# Altium schematic sheet TI_92_power.SchDoc
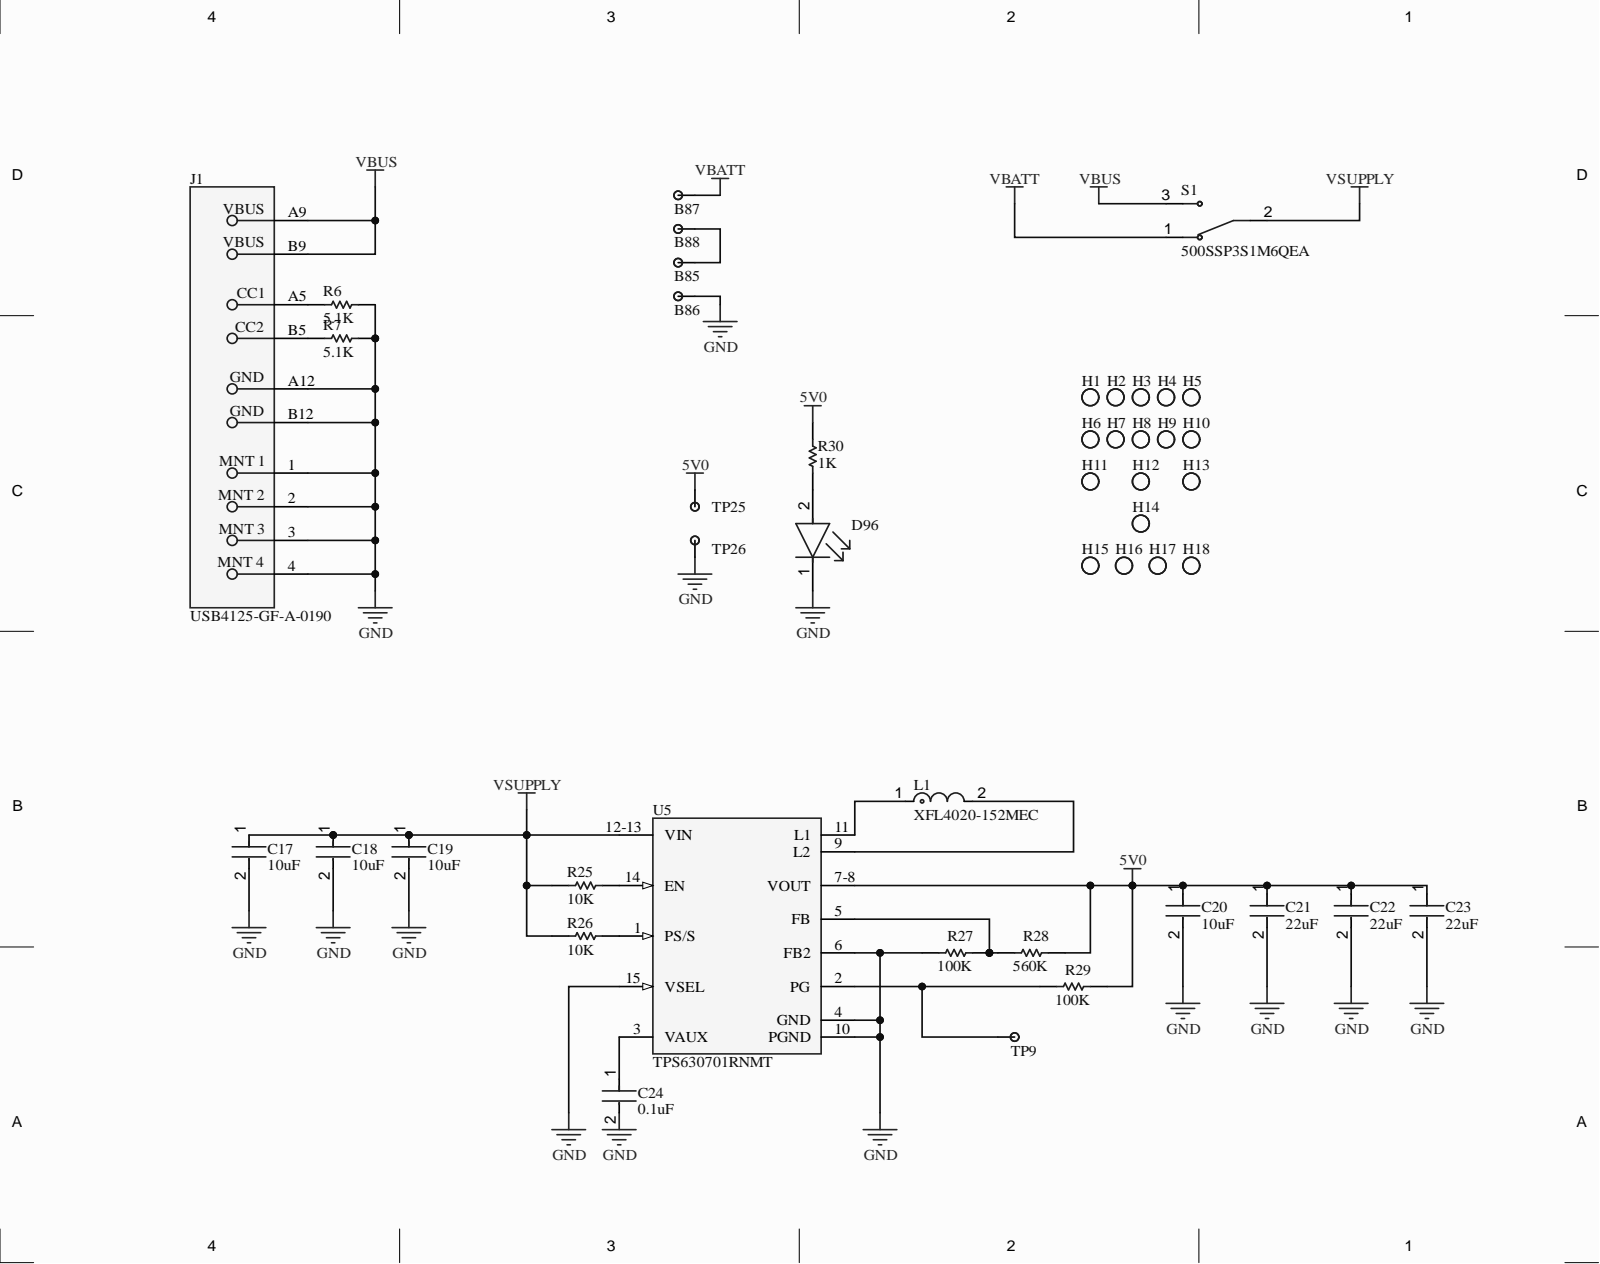
[diagram p0: TI_92_power.SchDoc]

## Parts
B85  Test Pad  [10mm_x_10mm_PAD]  (value not drawn)
B86  Test Pad  [10mm_x_8mm_PAD]  (value not drawn)
B87  Test Pad  [10mm_x_10mm_PAD]  (value not drawn)
B88  Test Pad  [10mm_x_8mm_PAD]  (value not drawn)
C17  10uF  [FP-GRM21B-0_2-e0_2_0_7-IPC_A]
C18  10uF  [FP-GRM21B-0_2-e0_2_0_7-IPC_A]
C19  10uF  [FP-0603-L_1_6_0_2-W_0_8_0-MFG]
C20  10uF  [FP-0603-L_1_6_0_2-W_0_8_0-MFG]
C21  22uF  [FP-GRM21B-0_2-e0_2_0_7-MFG]
C22  22uF  [FP-GRM21B-0_2-e0_2_0_7-MFG]
C23  22uF  [FP-GRM21B-0_2-e0_2_0_7-MFG]
C24  0.1uF  [FP-0402-L_1_0_0_05-W_0_5-IPC_B]
D96  APHHS1005CGCK  [FP-APHHS1005CGCK-MFG]  (value not drawn)
H1  Mounting Hole  [2.7mm_HOLE]  (value not drawn)
H2  Mounting Hole  [2.7mm_HOLE]  (value not drawn)
H3  Mounting Hole  [1.5mm_hole]  (value not drawn)
H4  Mounting Hole  [2.7mm_HOLE]  (value not drawn)
H5  Mounting Hole  [2.7mm_HOLE]  (value not drawn)
H6  Mounting Hole  [2.7mm_HOLE]  (value not drawn)
H7  Mounting Hole  [2.7mm_HOLE]  (value not drawn)
H8  Mounting Hole  [2.7mm_HOLE]  (value not drawn)
H9  Mounting Hole  [2.7mm_HOLE]  (value not drawn)
H10  Mounting Hole  [2.7mm_HOLE]  (value not drawn)
H11  Mounting Hole  [2.4mm_HOLE]  (value not drawn)
H12  Mounting Hole  [2.4mm_HOLE]  (value not drawn)
H13  Mounting Hole  [2.4mm_HOLE]  (value not drawn)
H14  Mounting Hole  [1.5mm_hole]  (value not drawn)
H15  Mounting Hole  [3.1mm_HOLE]  (value not drawn)
H16  Mounting Hole  [3.1mm_HOLE]  (value not drawn)
H17  Mounting Hole  [3.1mm_HOLE]  (value not drawn)
H18  Mounting Hole  [3.1mm_HOLE]  (value not drawn)
J1  USB4125-GF-A-0190  [FP-USB4125-GF-A-0190-MFG]
L1  XFL4020-152MEC  [FP-XFL4020-MFG]
R6  5.1K  [RESC1005X40X25NL05T10]
R7  5.1K  [RESC1005X40X25NL05T10]
R25  10K  [RESC1005X40X25NL05T10]
R26  10K  [RESC1005X40X25NL05T10]
R27  100K  [RESC1005X40X25NL05T10]
R28  560K  [RESC1005X40X25NL05T10]
R29  100K  [RESC1005X40X25NL05T10]
R30  1K  [RESC1005X40X25NL05T10]
S1  500SSP3S1M6QEA  [SW_500SSP3S1M6QEA]
TP9  Test Pad  [1.5MM_PAD]  (value not drawn)
TP25  Test Pad  [2.5MM_PAD]  (value not drawn)
TP26  Test Pad  [2.5MM_PAD]  (value not drawn)
U5  TPS630701RNMT  [FP-RNM0015A-MFG]

## Nets
5V0: C20.1 C21.1 C22.1 C23.1 R28.2 R29.2 R30.2 TP25.1 U5.7-8(VOUT)
GND: B86.1 C17.2 C18.2 C19.2 C20.2 C21.2 C22.2 C23.2 C24.2 D96.1 J1.1 J1.2 J1.3 J1.4 J1.A12 J1.B12 R6.1 R7.1 R27.1 TP26.1 U5.4(GND) U5.6(FB2) U5.10(PGND) U5.15(VSEL)
VBATT: B87.1 S1.1
VBUS: J1.A9 J1.B9 S1.3
VSUPPLY: C17.1 C18.1 C19.1 R25.2 R26.2 S1.2 U5.12-13(VIN)
NetB85_1: B85.1 B88.1
NetC24_1: C24.1 U5.3(VAUX)
NetD96_2: D96.2 R30.1
NetJ1_A5: J1.A5 R6.2
NetJ1_B5: J1.B5 R7.2
NetL1_1: L1.1 U5.11(L1)
NetL1_2: L1.2 U5.9(L2)
NetR25_1: R25.1 U5.14(EN)
NetR26_1: R26.1 U5.1(PS/S)
NetR27_2: R27.2 R28.1 U5.5(FB)
NetR29_1: R29.1 TP9.1 U5.2(PG)

Not connected: H1 1; H2 1; H3 1; H4 1; H5 1; H6 1; H7 1; H8 1; H9 1; H10 1; H11 1; H12 1; H13 1; H14 1; H15 1; H16 1; H17 1; H18 1

## Library data of the parts
B85-B88 TP9 TP25 TP26 = Test_Pad: Various Test Pads; Component Kind: Standard; Library Name: TI_kbd.SchLib
C17 C18 = CMP-06035-056773-1: Chip Multilayer Ceramic Capacitors for General Purpose, 0805, 10uF, X7S, 22%, 20%, 25V; Capacitance: 10uF; Case Code (Imperial): 0805; Case Code (Metric): 2012; Case/Package: 0805; Component Kind: Standard; Dielectric: X7S; Height: 1.25mm; Length: 0.079inch; Library Name: Altium Content Vault; Max Operating Temperature: 125°C; Min Operating Temperature: -55°C; Mount: Surface Mount; Package Quantity: 3000; Packaging: Tape and Reel; Termination: SMD/SMT; Thickness: 0.057inch; Tolerance: 20%; Voltage Rating: 25V; Voltage Rating (DC): 25V; Weight: 0.000335oz; Width: 0.049inch
C19 C20 = CMP-14477-005164-2: Multilayer Ceramic Capacitor 10uF 25V X5R 20% SMD 0603 T/R; Capacitance: 10uF; Case Code (Imperial): 0603; Case Code (Metric): 1608; Case/Package: 0603; Component Kind: Standard; Lead Free: Lead Free; Library Name: Altium Content Vault; Max Operating Temperature: 85°C; Min Operating Temperature: -55°C; Mount: Surface Mount; Packaging: Tape and Reel; RoHS Compliant: Yes; Tolerance: 20%; Voltage Rating: 25V
C21-C23 = CMP-06035-012913-1: Chip Multilayer Ceramic Capacitors for General Purpose, 0805, 22uF, X6S, 22%, 20%, 16V; Capacitance: 22uF; Case Code (Imperial): 0805; Case Code (Metric): 2012; Case/Package: 0805; Component Kind: Standard; Dielectric: X6S; Height: 1.25mm; Lead Free: Lead Free; Length: 0.079inch; Library Name: Altium Content Vault; Max Operating Temperature: 105°C; Min Operating Temperature: -55°C; Mount: Surface Mount; Package Quantity: 3000; Packaging: Tape and Reel; Termination: SMD/SMT; Thickness: 0.057inch; Tolerance: 20%; Voltage Rating: 16V; Voltage Rating (DC): 16V; Weight: 0.000335oz; Width: 1.25mm
C24 = CMP-14477-003834-2: None; Capacitance: 100nF; Tolerance: 20%; Voltage Rating: 25V; Case/Package: 0402; Component Kind: Standard; Library Name: Altium Content Vault; Max Operating Temperature: 125°C; Min Operating Temperature: -55°C; Mount: Surface Mount; Packaging: Tape and Reel; RoHS Compliant: Yes; Simulation: TMK105B7104_V-FR
D96 = CMP-44529-000044-1: LED GREEN CLEAR CHIP SMD; Dominant Wavelength: 570nm; Forward Current: 20mA; Forward Voltage: 2.1V; Luminous Intensity: 50mcd; Number of LEDs: 1; Viewing Angle: 120°; Case Code (Imperial): 0402; Case Code (Metric): 1005; Case/Package: 0402; Color: Green; Component Kind: Standard; Height: 0.6mm; Illumination Color: Green; Lead Free: Lead Free; Length: 1mm; Lens Color: Clear; Lens Style: Clear; Library Name: Altium Content Vault; Max Operating Temperature: 85°C; Min Operating Temperature: -40°C; Mount: Surface Mount; Number of Pins: 2; Package Quantity: 2000; Packaging: Tape and Reel; Test Current: 20mA; Wavelength: 570nm; Width: 0.5mm
H1-H18 = Mounting_Hole: Various Mounting Holes; Component Kind: Standard; Library Name: TI_kbd.SchLib
J1 = CMP-245163-000004-1: CONN RCPT TYPE C 6P SMD RA; Component Kind: Standard; Library Name: Altium Content Vault
L1 = CMP-11268-000104-1: Inductor Power Shielded Wirewound 1.5uH 20% 100KHz 9.1A 15.8mOhm DCR 1515 Automotive Embossed Plastic T/R; Core Material: Composite; DC Resistance (DCR): 15.8mR; Saturation Current: 4.1A; Self Resonant Frequency: 59MHz; Case/Package: SMD/SMT; Component Kind: Standard; Height: 2.1mm; Inductance: 1.5uH; Inductance Tolerance: 20%; Lead Free: Contains Lead; Length: 4.3mm; Library Name: Altium Content Vault; Max DC Current: 9.1A; Max Operating Temperature: 125°C; Min Operating Temperature: -40°C; Mount: Surface Mount; Packaging: Tape and Reel; REACH SVHC: No SVHC; RMS Current (Irms): 9.1A; RoHS Compliant: Yes; Series: XFL4020; Shielding: Shielded; Termination: SMD/SMT; Test Frequency: 100kHz; Tolerance: 20%; Width: 4.3mm
R6 R7 R25-R30 = CMP-2002-07472-1: Manufacturer: Yageo / Phycomp; Part Number: RC0402FR-071KL; Component Kind: Standard; Library Name: Altium Content Vault
U5 = CMP-04918-000497-1: IC REG BCK BST 5V 2A 15VQFN; Max Input Voltage: 16V; Min Input Voltage: 2V; Quiescent Current: 50uA; Switching Frequency: 2.4MHz; Topology: Buck-Boost; Component Kind: Standard; Lead Free: Lead Free; Library Name: Altium Content Vault; Max Operating Temperature: 125°C; Nominal Output Voltage: 5V; Number of Pins: 15; Output Current: 2A; Packaging: Cut Tape; REACH SVHC: No SVHC; RoHS Compliant: Yes
S1 = 500SSP3S1M6QEA: Availability: Unavailable; Component Kind: Standard; Library Name: 500SSP3S1M6QEA.IntLib; MP: 500SSP3S1M6QEA; Package: None; Price: None
